# Altium schematic sheet Extra2_temp_amp.SchDoc
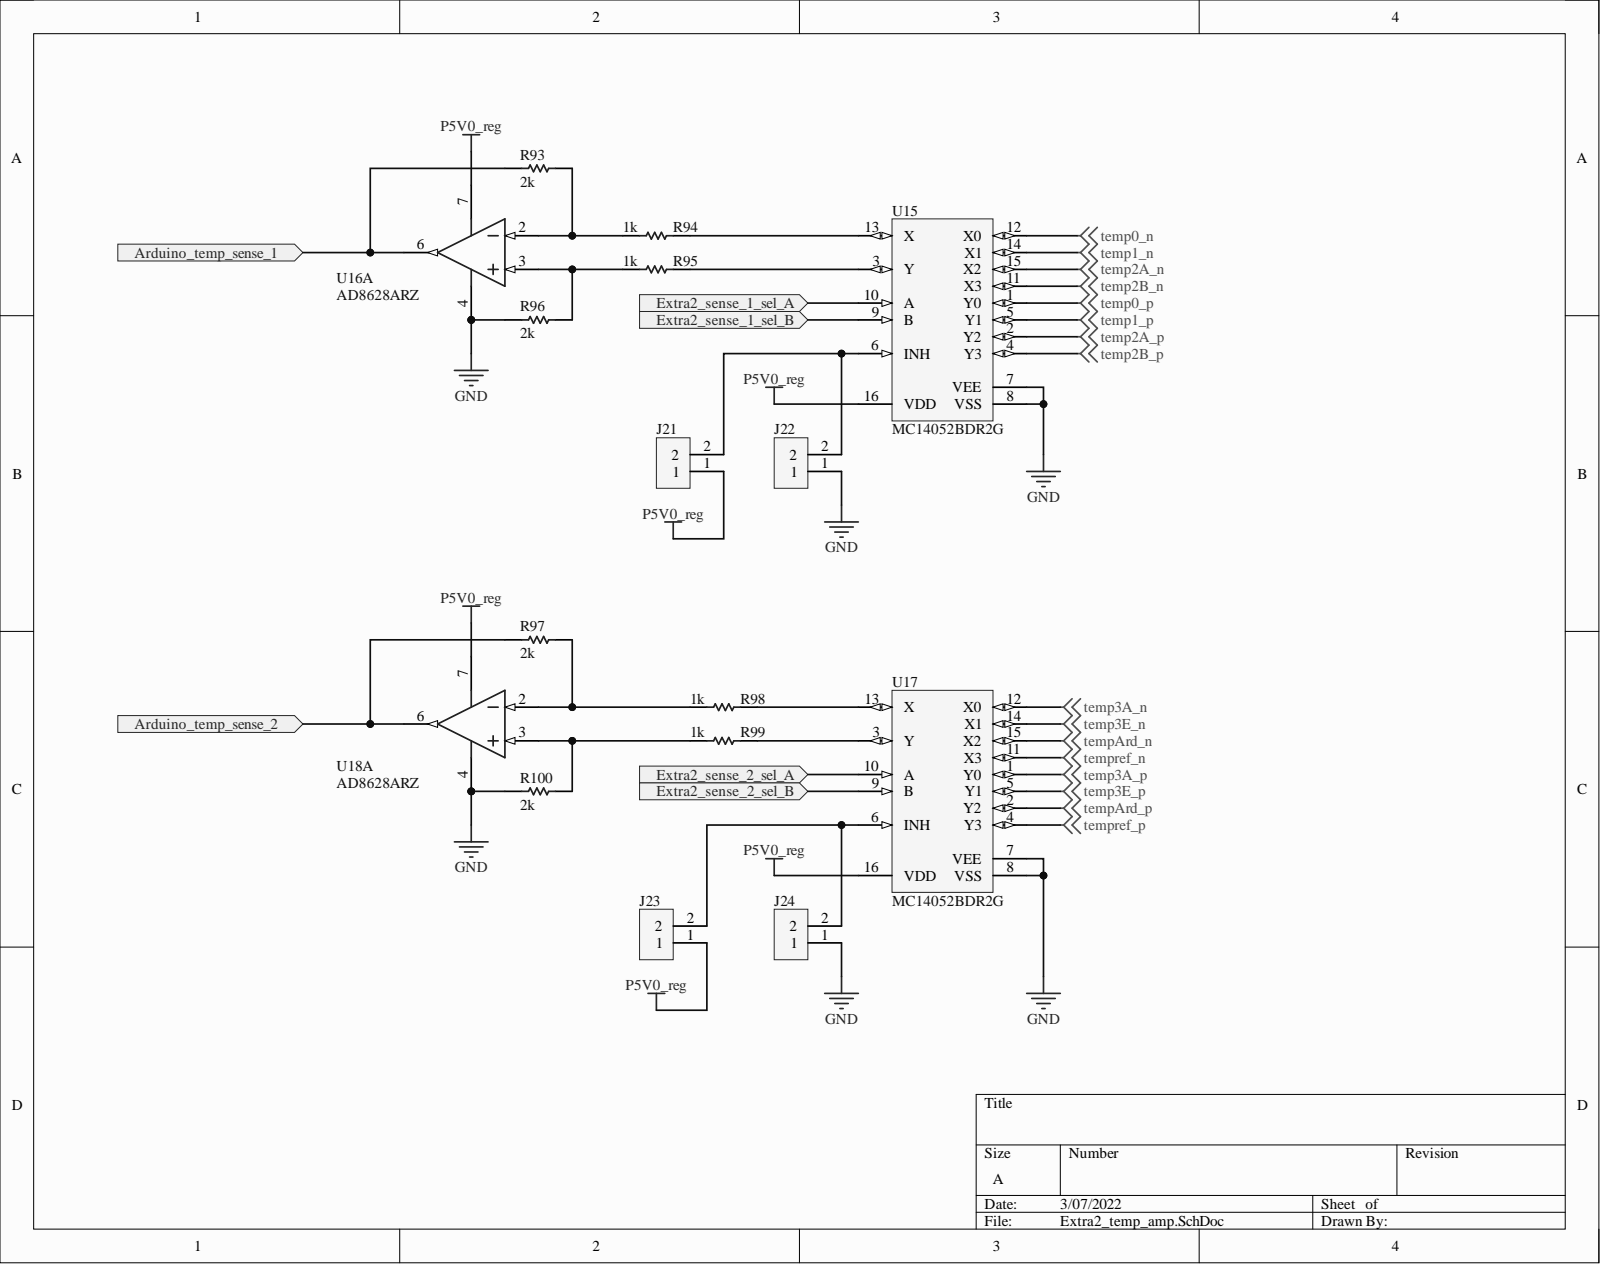
[diagram p0: Extra2_temp_amp.SchDoc]

## Parts
J21  (no value)  [PCB-omgsbkhp90n7lpri88og-1]
J22  (no value)  [PCB-omgsbkhp90n7lpri88og-1]
J23  (no value)  [PCB-omgsbkhp90n7lpri88og-1]
J24  (no value)  [PCB-omgsbkhp90n7lpri88og-1]
R93  2k  [PCB-0002-00743-1]
R94  1k  [PCB-inhgnewug1oc5mowfht0-1]
R95  1k  [PCB-inhgnewug1oc5mowfht0-1]
R96  2k  [PCB-0002-00743-1]
R97  2k  [PCB-0002-00743-1]
R98  1k  [PCB-inhgnewug1oc5mowfht0-1]
R99  1k  [PCB-inhgnewug1oc5mowfht0-1]
R100  2k  [PCB-0002-00743-1]
U15  MC14052BDR2G  [ONSC-SOIC-16-751B-05_V]
U16  AD8628ARZ  [ADI-R-8_L]  (part A)
U17  MC14052BDR2G  [ONSC-SOIC-16-751B-05_V]
U18  AD8628ARZ  [ADI-R-8_L]  (part A)

## Ports
Arduino_temp_sense_1, Arduino_temp_sense_2, Extra2_sense_1_sel_A, Extra2_sense_1_sel_B, Extra2_sense_2_sel_A, Extra2_sense_2_sel_B

## Nets
GND: J22.1 J24.1 R96.1 R100.1 U15.7(VEE) U15.8(VSS) U16.4 U17.7(VEE) U17.8(VSS) U18.4
P5V0_reg: J21.1 J23.1 U15.16(VDD) U16.7 U17.16(VDD) U18.7
temp0_n: U15.12(X0)
temp0_p: U15.1(Y0)
temp1_n: U15.14(X1)
temp1_p: U15.5(Y1)
temp2A_n: U15.15(X2)
temp2A_p: U15.2(Y2)
temp2B_n: U15.11(X3)
temp2B_p: U15.4(Y3)
temp3A_n: U17.12(X0)
temp3A_p: U17.1(Y0)
temp3E_n: U17.14(X1)
temp3E_p: U17.5(Y1)
tempArd_n: U17.15(X2)
tempArd_p: U17.2(Y2)
tempref_n: U17.11(X3)
tempref_p: U17.4(Y3)
Arduino_temp_sense_1: R93.1 U16.6 ; port Arduino_temp_sense_1
Arduino_temp_sense_2: R97.1 U18.6 ; port Arduino_temp_sense_2
Extra2_sense_1_sel_A: U15.10(A) ; port Extra2_sense_1_sel_A
Extra2_sense_1_sel_B: U15.9(B) ; port Extra2_sense_1_sel_B
Extra2_sense_2_sel_A: U17.10(A) ; port Extra2_sense_2_sel_A
Extra2_sense_2_sel_B: U17.9(B) ; port Extra2_sense_2_sel_B
NetJ21_2: J21.2 J22.2 U15.6(INH)
NetJ23_2: J23.2 J24.2 U17.6(INH)
NetR93_2: R93.2 R94.1 U16.2
NetR94_2: R94.2 U15.13(X)
NetR95_1: R95.1 R96.2 U16.3
NetR95_2: R95.2 U15.3(Y)
NetR97_2: R97.2 R98.1 U18.2
NetR98_2: R98.2 U17.13(X)
NetR99_1: R99.1 R100.2 U18.3
NetR99_2: R99.2 U17.3(Y)

## Library data of the parts
J21-J24 = CMP-748fbcb73d6bab48-30: Pitch 2.54 mm, Single Row, 2pins; Component Kind: Standard; Library Name: My Personal Space
R93 R96 R97 R100 = CMP-e4e22df909e63b25-3: Res Thick Film 0805 3K Ohm 1% 0.125W(1/8W) ±100ppm/C Pad SMD T/R; Manufacturer Part Number: RC0805FR-073KL; Case/Package (SI): 2012; Lead-Free Status: Lead Free; Power Rating: 125 mW; Resistance: 3.00 kΩ; Temperature Coefficient: -100 ppm/°C to 100 ppm/°C; Voltage Rating (DC): 150 V; Case/Package: 0805; Component Kind: Standard; Library Name: My Personal Space; Mounting Style: Surface Mount; Octopart URL: https://octopart.com/rc0805fr-073kl-yageo-1165410; OctopartID: e4e22df909e63b25; Operating Temperature: 155 °C (max); Packaging: Tape & Reel; Resistance Tolerance: ±1%; RoHS: Compliant; Size-Height: 500 µm; Size-Length: 2.00 mm; Size-Width: 1.25 mm
R94 R95 R98 R99 = CMP-5a329075facc85f5-3: Res Thin Film 0805 1K Ohm 0.1% 1/8W ±10ppm/°C Molded SMD SMD Punched Carrier T/R; Component Kind: Standard; Library Name: My Personal Space
U15 U17 = CMP-1254194-2: Analog Multiplexer / Demultiplexer, 3 to 18 V, -55 to 125 degC, 16-Pin SOIC, Pb-Free, Tape and Reel; Bandwidth: 17000000.0 Hz; Contacts Type: SP4T; Lead-Free Status: Lead Free; Supply Voltage (DC): 18.0 V; Case/Package: SOIC; Component Kind: Standard; Halogen Free Status: Halogen Free; Library Name: My Personal Space; Lifecycle Status: Active; Manufacturer Part Number: MC14052BDR2G; Mounting Style: Surface Mount; Number of Pins: 16; Octopart Url: https://octopart.com/mc14052bdr2g-on+semiconductor-473537; OctopartID: 07daced71b7dd81d; Packaging: Cut Tape (CT); RoHS: Compliant
U16 U18 = CMP-2260891-3: Single Channel RRIO Operational Amplifier, 2.5 MHz BW, 1 V/us SR, Industrial, 8-pin SOIC (R-8), Tube; Bandwidth: 2500000.0 Hz; Common Mode Rejection Ratio: 140.0 dB; Input Capacitance: 1e-11 F; Input Impedance: 5e+11 Ω; Lead-Free Status: Contains Lead; Mil-Spec: No; Number of Channels: 1; Slew Rate: 1.0 V/μs; Supply Current: 0.0011 A; Supply Voltage (DC): 5.0 V; Case/Package: SOIC; Component Kind: Standard; Gain Bandwidth Product: 2500000.0 Hz; Library Name: My Personal Space; Lifecycle Status: Active; Manufacturer Part Number: AD8628ARZ; Mounting Style: Surface Mount; Number of Circuits: 1; Number of Pins: 8; Octopart Url: https://octopart.com/ad8628arz-analog+devices-412641; OctopartID: cd22042ea3a512e5; Packaging: Tube; REACH SVHC Compliance: No SVHC; RoHS: Compliant
